# Altium schematic sheet TPVSI_Inverter_Voltage_Sensing.SchDoc(TPVSI_Inverter_Voltage_Sensing)
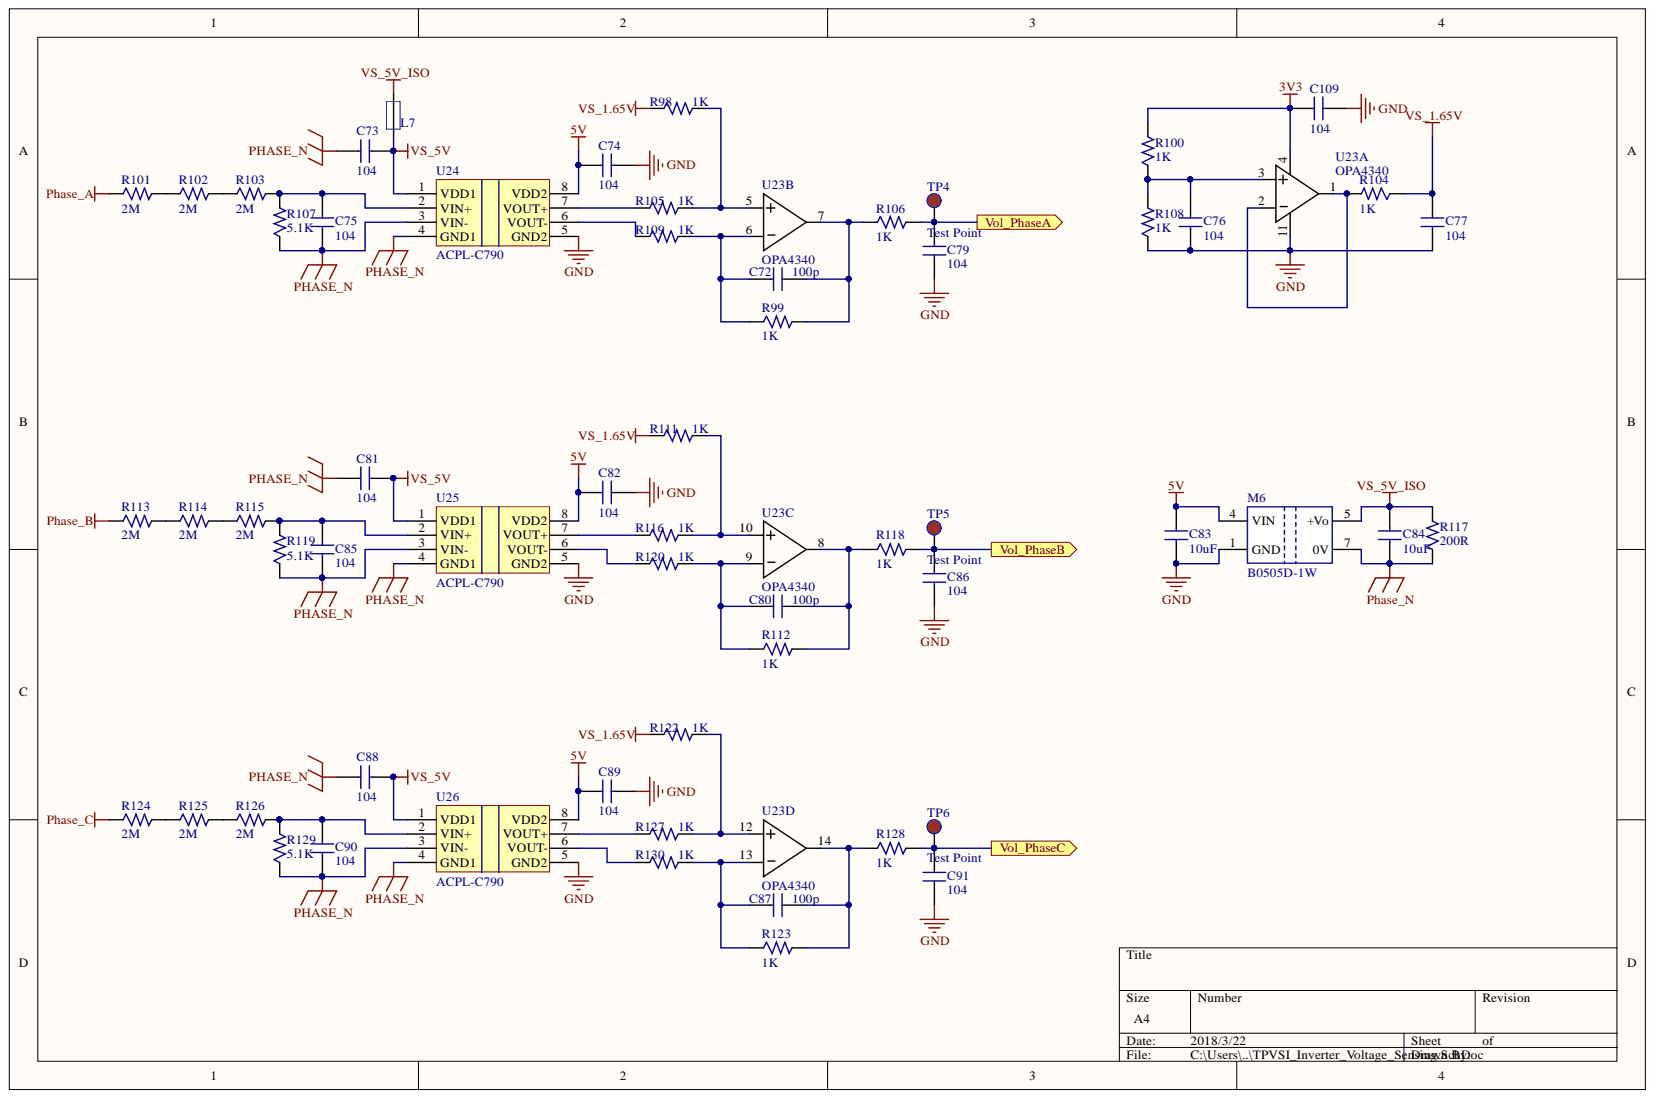
[diagram p0: TPVSI_Inverter_Voltage_Sensing.SchDoc]

## Parts
C72  100p  [C-RAD-0603]
C73  104  [C-RAD-0603]
C74  104  [C-RAD-0603]
C75  104  [C-RAD-0603]
C76  104  [C-RAD-0603]
C77  104  [C-RAD-0603]
C79  104  [C-RAD-0603]
C80  100p  [C-RAD-0603]
C81  104  [C-RAD-0603]
C82  104  [C-RAD-0603]
C83  10uF  [1210]
C84  10uF  [1210]
C85  104  [C-RAD-0603]
C86  104  [C-RAD-0603]
C87  100p  [C-RAD-0603]
C88  104  [C-RAD-0603]
C89  104  [C-RAD-0603]
C90  104  [C-RAD-0603]
C91  104  [C-RAD-0603]
C109  104  [C-RAD-0603]
L7  Inductor_Small  [1206]  (value not drawn)
M6  B0505D-1W  [B0505D-1W]
R98  1K  [0603_1]
R99  1K  [0603_1]
R100  1K  [0603_1]
R101  2M  [2512]
R102  2M  [2512]
R103  2M  [2512]
R104  1K  [0603_1]
R105  1K  [0603_1]
R106  1K  [0603_1]
R107  5.1K  [0603_1]
R108  1K  [0603_1]
R109  1K  [0603_1]
R111  1K  [0603_1]
R112  1K  [0603_1]
R113  2M  [2512]
R114  2M  [2512]
R115  2M  [2512]
R116  1K  [0603_1]
R117  200R  [1206]
R118  1K  [0603_1]
R119  5.1K  [0603_1]
R120  1K  [0603_1]
R122  1K  [0603_1]
R123  1K  [0603_1]
R124  2M  [2512]
R125  2M  [2512]
R126  2M  [2512]
R127  1K  [0603_1]
R128  1K  [0603_1]
R129  5.1K  [0603_1]
R130  1K  [0603_1]
TP4  Test Point  [TestPointOrange]
TP5  Test Point  [TestPointOrange]
TP6  Test Point  [TestPointOrange]
U23  OPA4340  [SOP-14N]  (parts A,B,C,D)
U24  ACPL-C790  [SSO8]
U25  ACPL-C790  [SSO8]
U26  ACPL-C790  [SSO8]

## Ports
Vol_PhaseA, Vol_PhaseB, Vol_PhaseC

## Nets
3V3: C109.2 R100.2 U23.4
5V: C74.1 C82.1 C83.2 C89.1 M6.4(VIN) U24.8(VDD2) U25.8(VDD2) U26.8(VDD2)
GND: C74.2 C76.1 C77.1 C79.1 C82.2 C83.1 C86.1 C89.2 C91.1 C109.1 M6.1(GND) R108.1 U23.11 U24.5(GND2) U25.5(GND2) U26.5(GND2)
Phase_A: R101.1
Phase_B: R113.1
Phase_C: R124.1
PHASE_N: C73.1 C75.1 C81.1 C84.1 C85.1 C88.1 C90.1 M6.7(0V) R107.1 R117.1 R119.1 R129.1 U24.3(VIN-) U24.4(GND1) U25.3(VIN-) U25.4(GND1) U26.3(VIN-) U26.4(GND1)
VS_1.65V: C77.2 R98.1 R104.1 R111.1 R122.1
VS_5V: C73.2 C81.2 C88.2 L7.1 U24.1(VDD1) U25.1(VDD1) U26.1(VDD1)
VS_5V_ISO: C84.2 L7.2 M6.5(+Vo) R117.2
Vol_PhaseA: C79.2 R106.2 TP4.1 ; port Vol_PhaseA
Vol_PhaseB: C86.2 R118.2 TP5.1 ; port Vol_PhaseB
Vol_PhaseC: C91.2 R128.2 TP6.1 ; port Vol_PhaseC
NetC72_1: C72.1 R99.1 R109.2 U23.6
NetC72_2: C72.2 R99.2 R106.1 U23.7
NetC75_2: C75.2 R103.2 R107.2 U24.2(VIN+)
NetC76_2: C76.2 R100.1 R108.2 U23.3
NetC80_1: C80.1 R112.1 R120.2 U23.9
NetC80_2: C80.2 R112.2 R118.1 U23.8
NetC85_2: C85.2 R115.2 R119.2 U25.2(VIN+)
NetC87_1: C87.1 R123.1 R130.2 U23.13
NetC87_2: C87.2 R123.2 R128.1 U23.14
NetC90_2: C90.2 R126.2 R129.2 U26.2(VIN+)
NetR98_2: R98.2 R105.2 U23.5
NetR101_2: R101.2 R102.1
NetR102_2: R102.2 R103.1
NetR104_2: R104.2 U23.1 U23.2
NetR105_1: R105.1 U24.7(VOUT+)
NetR109_1: R109.1 U24.6(VOUT-)
NetR111_2: R111.2 R116.2 U23.10
NetR113_2: R113.2 R114.1
NetR114_2: R114.2 R115.1
NetR116_1: R116.1 U25.7(VOUT+)
NetR120_1: R120.1 U25.6(VOUT-)
NetR122_2: R122.2 R127.2 U23.12
NetR124_2: R124.2 R125.1
NetR125_2: R125.2 R126.1
NetR127_1: R127.1 U26.7(VOUT+)
NetR130_1: R130.1 U26.6(VOUT-)

## Library data of the parts
C72-C77 C79-C91 C109 = cap: Component Kind: Standard; Library Name: Chu_16.IntLib
R98-R109 R111-R120 R122-R130 = Res: Component Kind: Standard; Library Name: Chu_16.IntLib
TP4-TP6 = TP1: 测试点; Component Kind: Standard; Library Name: Chu_16.IntLib
L7 = Inductor_Small: Component Kind: Standard; Library Name: Chu_16.IntLib
M6 = B0505D-1W: Component Kind: Standard; Library Name: Chu_16.IntLib
U23 = OPA4340: Component Kind: Standard; Library Name: Chu_16.IntLib
U24-U26 = ACPL-C790: Component Kind: Standard; Library Name: Chu_16.IntLib
